# Altium schematic sheet Input OUTPUT.SchDoc
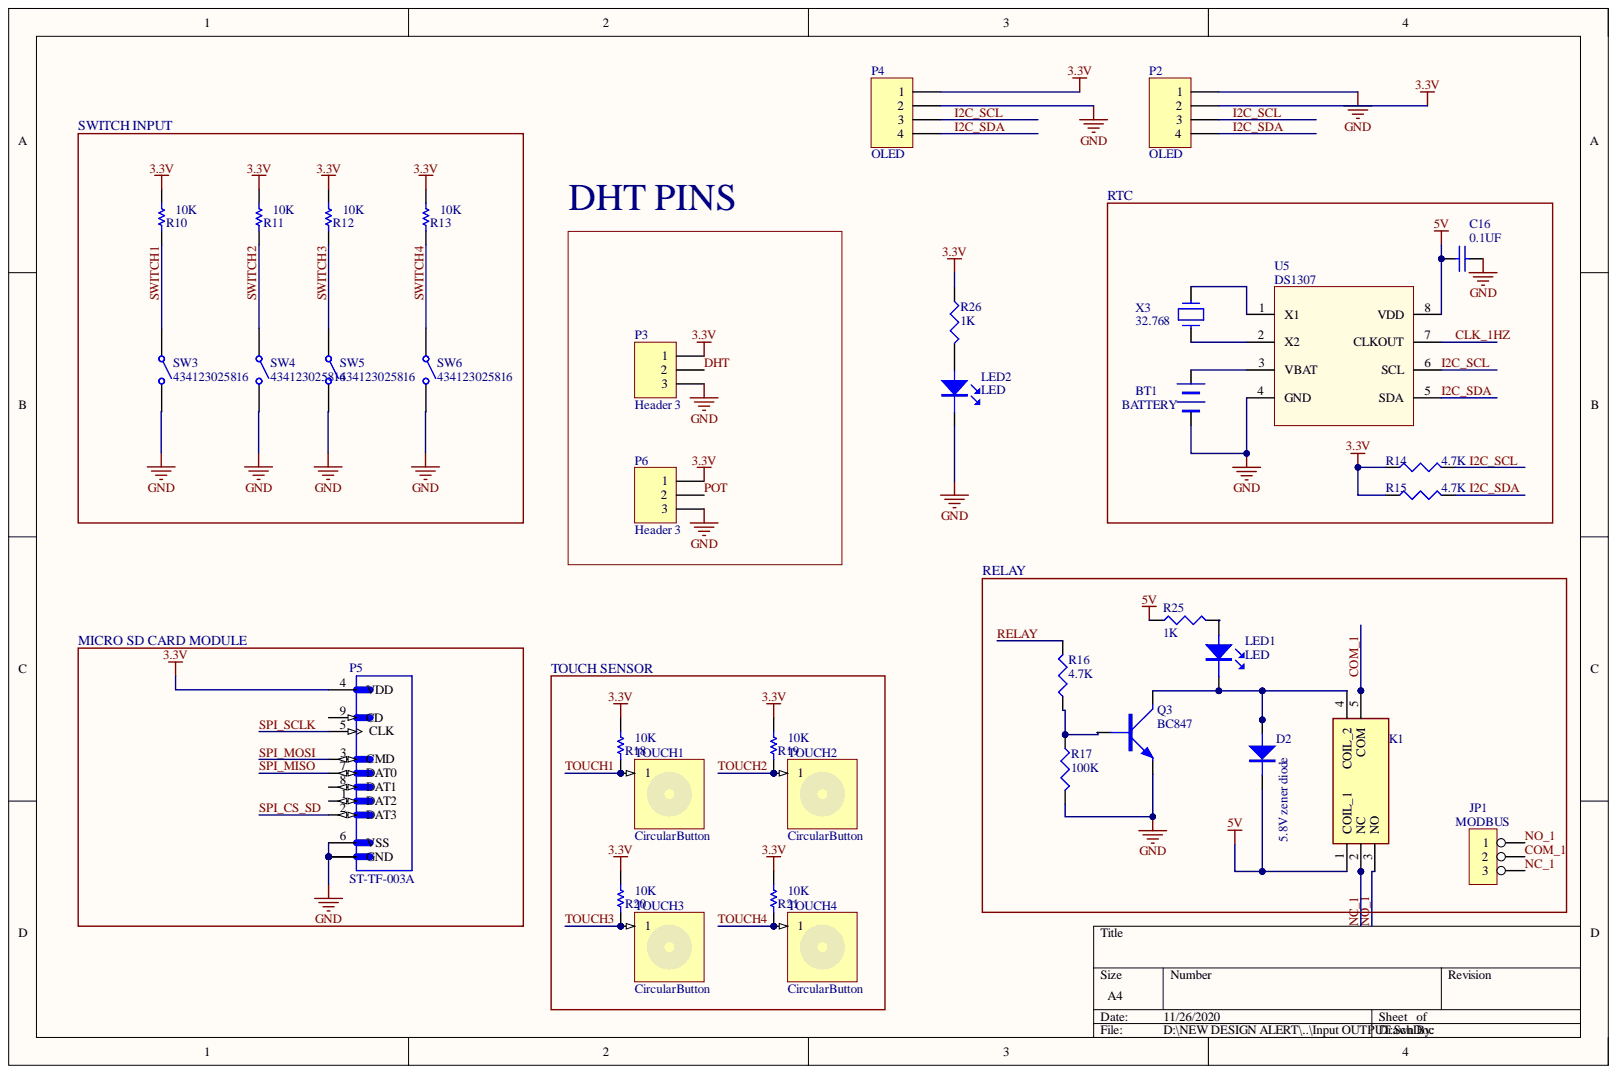
[diagram p0: Input OUTPUT.SchDoc]

## Parts
BT1  BATTERY  [CR2032_HOLDER_CH28]
C16  0.1UF  [CAPC0805]
D2  5.8V zener diode  [BZT55B13GS08]
JP1  MODBUS  [TERMINAL_BLOCK_3PIN_5.08MM_RA]
K1  0476618  [0476618]  (value not drawn)
LED1  LED  [LED0805]
LED2  LED  [LED0805]
P2  OLED  [HDR1X4]
P3  Header 3  [HDR1X3]
P4  OLED  [HDR1X4]
P5  ST-TF-003A  [SUNTECH_ST-TF-003A]
P6  Header 3  [HDR1X3]
Q3  BC847  [SOT23-3]
R10  10K  [RESC0603]
R11  10K  [RESC0603]
R12  10K  [RESC0603]
R13  10K  [RESC0603]
R14  4.7K  [RESC0805]
R15  4.7K  [RESC0805]
R16  4.7K  [RESC0805]
R17  100K  [RESC0805]
R18  10K  [RESC0603]
R19  10K  [RESC0603]
R20  10K  [RESC0603]
R21  10K  [RESC0603]
R25  1K  [RESC0805]
R26  1K  [RESC0805]
SW3  434123025816  [434123025816]
SW4  434123025816  [434123025816]
SW5  434123025816  [434123025816]
SW6  434123025816  [434123025816]
TOUCH1  CircularButton  (no BOM)
TOUCH2  CircularButton  (no BOM)
TOUCH3  CircularButton  (no BOM)
TOUCH4  CircularButton  (no BOM)
U5  DS1307  [SOIC8]
X3  32.768  [CRYSTAL_32.768_SMD]

## Nets
3.3V: P2.2 P3.1 P4.1 P5.4(VDD) P6.1 R10.2 R11.2 R12.2 R13.2 R14.1 R15.1 R18.2 R19.2 R20.2 R21.2 R26.1
5V: C16.2 D2.2 K1.1(COIL_1) R25.1 U5.8(VDD)
GND: BT1.2 C16.1 LED2.2 P2.1 P3.3 P4.2 P5.6(VSS) P5.G1(GND) P5.G2 P5.G3 P5.G4 P6.3 Q3.2 R17.2 SW3.2 SW4.2 SW5.2 SW6.2 U5.4(GND)
CLK_1HZ: U5.7(CLKOUT)
COM_1: JP1.2 K1.5(COM)
DHT: P3.2
I2C_SCL: P2.3 P4.3 R14.2 U5.6(SCL)
I2C_SDA: P2.4 P4.4 R15.2 U5.5(SDA)
NC_1: JP1.3 K1.2(NC)
NO_1: JP1.1 K1.3(NO)
POT: P6.2
RELAY: R16.2
SPI_CS_SD: P5.2(DAT3)
SPI_MISO: P5.7(DAT0)
SPI_MOSI: P5.3(CMD)
SPI_SCLK: P5.5(CLK)
SWITCH1: R10.1 SW3.1
SWITCH2: R11.1 SW4.1
SWITCH3: R12.1 SW5.1
SWITCH4: R13.1 SW6.1
TOUCH1: R18.1 TOUCH1.1
TOUCH2: R19.1 TOUCH2.1
TOUCH3: R20.1 TOUCH3.1
TOUCH4: R21.1 TOUCH4.1
NetBT1_1: BT1.1 U5.3(VBAT)
NetD2_1: D2.1 K1.4(COIL_2) LED1.2 Q3.3
NetLED1_1: LED1.1 R25.2
NetLED2_1: LED2.1 R26.2
NetQ3_1: Q3.1 R16.1 R17.1
NetU5_1: U5.1(X1) X3.1
NetU5_2: U5.2(X2) X3.2

Not connected: P5 1(DAT2) 8(DAT1) 9(CD)

## Library data of the parts
C16 = CAP NP: Component Kind: Standard; Library Name: Devices.SchLib
D2 = DIODE: Component Kind: Standard; Library Name: Devices.SchLib
JP1 = HEADER 3: Component Kind: Standard; Library Name: Devices.SchLib
K1 = 0476618: Relay or Contactor; Manufacturer_Name: RS Components; Component Kind: Standard; Datasheet Link: https://docs-emea.rs-online.com/webdocs/1583/0900766b81583163.pdf; Height: 15mm; Manufacturer_Part_Number: 0476618
P2 P4 = Header 4: Header, 4-Pin; Component Kind: Standard; LatestRevisionDate: 17-Jul-2002; LatestRevisionNote: Re-released for DXP Platform.; Library Name: Miscellaneous Connectors.IntLib; Signal Integrity: Connector; Publisher: Altium Limited
P3 P6 = Header 3: Header, 3-Pin; Component Kind: Standard; LatestRevisionDate: 17-Jul-2002; LatestRevisionNote: Re-released for DXP Platform.; Library Name: Miscellaneous Connectors.IntLib; Signal Integrity: Connector; Publisher: Altium Limited
P5 = ST-TF-003A: Micro SD Card Socket; MF: Suntech; Availability: Unavailable; Component Kind: Standard; Library Name: ST-TF-003A.IntLib; MP: ST-TF-003A; Package: None; Price: None; SnapEDA_Link: https://www.snapeda.com/parts/ST-TF-003A/Suntech/view-part/214778/?ref=snap
Q3 = NPN BEC: Component Kind: Standard; Library Name: Devices.SchLib
R10-R13 R18-R21 = CMP-1013-00215-1: 2R55 0.125W 1% 0805 (2012 Metric)  SMD; Case-EIA: 0805; Case-Metric: 2012; Value: 2.55 Ohm; Component Kind: Standard; Library Name: Components - 22.01.19 - 11.39.SchLib; Power: 0.125 W; Technology: SMT; Tolerance: 1 %
R14-R17 R25 R26 = RES1: RESISTOR; Component Kind: Standard
  R14 R15: Library Name: Components - 22.01.19 - 11.39.SchLib
  R16 R17 R25 R26: Library Name: Devices.SchLib
SW3-SW6 = CMP-1460-00004-1: WS-TASV J-Bend SMD Tact Switch 6.0X3.8 mm; Manufacturer: Wuerth Elektronik; NoPins: 02; Component Kind: Standard; ComponentLink1Description: Manufacturer URL; ComponentLink1URL: http://www.we-online.com; ComponentLink2Description: Datasheet; ComponentLink2URL: http://katalog.we-online.de/em/datasheet/434123025816.pdf; Library Name: Altium Content Vault; PackageReference: 434123025816; PartNumber: 434123025816
TOUCH1-TOUCH4 = CircularButton: ConfigurationParameters: {}__sensorid[CircularButton]{}; ConfiguratorName: CYPRESS_TOUCH; Description: CircularButton; Library Name: Cypress CapSense.IntLib
X3 = CRYSTAL: Component Kind: Standard; Library Name: Devices.SchLib
BT1 = BATTERY: Component Kind: Standard; Library Name: Devices.SchLib
LED1 LED2 = LED: Component Kind: Standard; Library Name: Devices.SchLib
U5 = DS1307: Component Kind: Standard; Library Name: CustomParts.SchLib
